# Altium schematic sheet Power.SchDoc(Power)
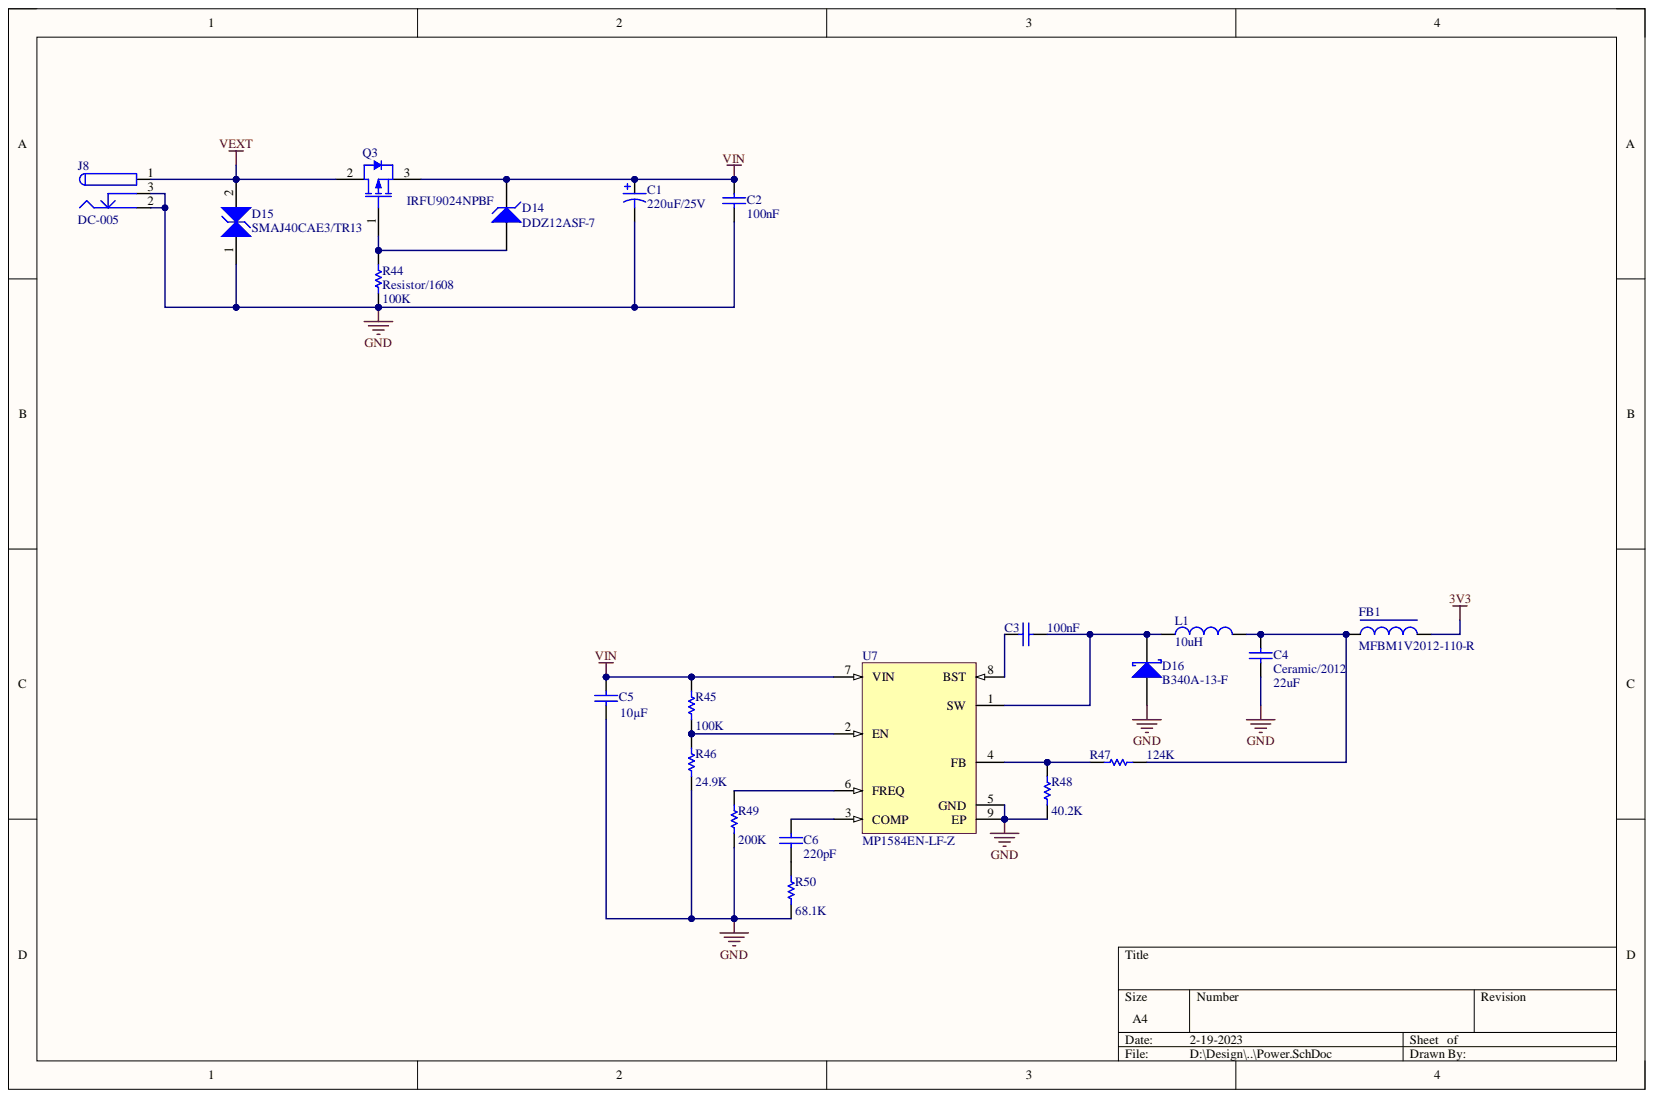
[diagram p0: Power.SchDoc]

## Parts
C1  value 220uF/25V  [THT Electrolytic 8x12x3.5mm]  (hidden comment: THT Electrolytic 8x12)
C2  value 100nF  [Generic 1608 Ceramic]  (hidden comment: Ceramic/1608)
C3  value 100nF  [Generic 1608-Footprint-1]  (hidden comment: Ceramic/1608)
C4  Ceramic/2012  value 22uF  [Ceramic_2012-Footprint-1]
C5  Ceramic/2012  value 10µF  [Ceramic_2012-Footprint-1]
C6  value 220pF  [Generic 1608-Footprint-1]  (hidden comment: Ceramic/1608)
D14  DDZ12ASF-7  [Diodes SOD323F-1]
D15  SMAJ40CAE3/TR13  [Microsemi_Bidirectional_SMA]
D16  B340A-13-F  [DIOD-SMA-2_V]
FB1  MFBM1V2012-110-R  [2508051027Y1-Footprint-1]
J8  DC-005  [DC-0005-Footprint-1]
L1  value 10uH  [YC104RT-10uH-Footprint-1]  (hidden comment: YC104RT-100M)
Q3  IRFU9024NPBF  [I-PAK_Vertical]
R44  Resistor/1608  value 100K  [Resistor 1608-Footprint]
R45  value 100K  [Generic 1608-Footprint-1]  (hidden comment: Generic 1608)
R46  value 24.9K  [Generic 1608-Footprint-1]  (hidden comment: Generic 1608)
R47  value 124K  [Generic 1608-Footprint-1]  (hidden comment: Generic 1608)
R48  value 40.2K  [Generic 1608-Footprint-1]  (hidden comment: Generic 1608)
R49  value 200K  [Generic 1608-Footprint-1]  (hidden comment: Generic 1608)
R50  value 68.1K  [Generic 1608-Footprint-1]  (hidden comment: Generic 1608)
U7  MP1584EN-LF-Z  [MP1584EN-LF-Z]

## Nets
3V3: FB1.2
GND: C1.2 C2.1 C4.1 C5.1 D15.1 D16.1 J8.2 J8.3 R44.1 R46.1 R48.1 R49.1 R50.1 U7.5(GND) U7.9(EP)
VEXT: D15.2 J8.1 Q3.2
VIN: C1.1 C2.2 C5.2 D14.1 Q3.3 R45.2 U7.7(VIN)
NetC3_1: C3.1 U7.8(BST)
NetC3_2: C3.2 D16.2 L1.1 U7.1(SW)
NetC4_2: C4.2 FB1.1 L1.2 R47.1
NetC6_1: C6.1 R50.2
NetC6_2: C6.2 U7.3(COMP)
NetD14_2: D14.2 Q3.1 R44.2
NetR45_1: R45.1 R46.2 U7.2(EN)
NetR47_2: R47.2 R48.2 U7.4(FB)
NetR49_2: R49.2 U7.6(FREQ)

## Library data of the parts
C1 = CMP-0001-00007-4: Generic THT Electrolytic capacitor; Capacitor Type: Electrolythic; Case/Package: Various; Component Kind: Standard; Library Name: C6H6`s Vault; Mount: THT; Pins: 2; RoHS: Yes
C2 = CMP-002-0009-3: Generic 1608 Ceramic Capacitor; Capacitor Type: Ceramic; Case/Package: 1608; Component Kind: Standard; Library Name: C6H6`s Vault; Mount: SMD; Pins: 2; RoHS: Yes
C3 C6 = CMP-002-0009-2: Generic 1608 Ceramic Capacitor; Capacitor Type: Ceramic; Case/Package: 1608; Component Kind: Standard; Library Name: C6H6`s Vault; Mount: SMD; Pins: 2; RoHS: Yes
C4 C5 = CMP-002-0022-1: Generic 2012 Ceramic Capacitor; Capacitor Type: Ceramic; Case/Package: 2012; Component Kind: Standard; Library Name: C6H6`s Vault; Mount: SMD; Pins: 2; RoHS: Yes
D14 = CMP-016-0031-1: Diode Zener Single 11.42V 3% 500mW 2-Pin SOD-323F T/R; Forward Voltage: 900mV; Impedance: 35R; Max Reverse Current: 70nA; Test Current: 10mA; Voltage Tolerance: 2.54%; Component Kind: Standard; Element Configuration: Single; Height: 0.75mm; Lead Free: Lead Free; Length: 2.5mm; Library Name: C6H6`s Vault; Max Operating Temperature: 150°C; Max Power Dissipation: 500mW; Max Reverse Leakage Current: 70nA; Min Operating Temperature: -65°C; Mount: Surface Mount; Number of Pins: 2; Package Quantity: 3000; Packaging: Tape and Reel; Power Rating: 500mW; Radiation Hardening: No; Reach SVHC Compliant: Yes; RoHS Compliant: Yes; Series: -; Tolerance: 3%; Width: 1.25mm; Zener Current: 70nA; Zener Voltage: 11.42V
D15 = CMP-00866-1: Diode TVS Single Bi-Dir 40V 500W 2-Pin DO-214AC T/R; Component Kind: Standard; Library Name: C6H6`s Vault
D16 = CMP-016-0009-1: DIODE SCHOTTKY 40V 3A SMA; Capacitance: 250pF; Element Configuration: Single; Forward Voltage: 500mV; Max Forward Surge Current (Ifsm): 80A; Peak Reverse Current: 500uA; Polarity: Standard; Average Rectified Current: 3A; Case/Package: SMA; Component Kind: Standard; Contact Plating: Tin,Matte; Current: 3A; Current Rating: 3A; Forward Current: 3A; Height: 2.3mm; Lead Free: Lead Free; Length: 4.6mm; Library Name: C6H6`s Vault; Max Operating Temperature: 125°C; Max Repetitive Reverse Voltage (Vrrm): 40V; Max Reverse Voltage (DC): 40V; Min Operating Temperature: -55°C; Mount: Surface Mount; Number of Pins: 2; Output Current: 3A; Package Quantity: 5000; Packaging: Tape and Reel; Peak Non-Repetitive Surge Current: 80A; Radiation Hardening: No; REACH SVHC: No SVHC; Termination: SMD/SMT; Voltage: 40V; Voltage Rating (DC): 40V; Weight: 0.002258oz; Width: 2.92mm
FB1 = CMP-ROOT-0224-1: Ferrite Chip Bead 11Ohm 6A 0.01Ohm Multi-Layer 2-Pin 0805 T/R; Test Frequency: 100MHz; Tolerance: 25%; Case/Package: 2012; Component Kind: Standard; Library Name: C6H6`s Vault; Termination: SMD/SMT; Width: 1.25mm
J8 = CMP-003-0019-2: DC Adapter Jack 2.0mm; Component Kind: Standard; Library Name: C6H6`s Vault
L1 = CMP-ROOT-00008-2: YC104RT-10uH 4.4A; Case/Package: 1040; Component Kind: Standard; Library Name: C6H6`s Vault; Mount: SMD; Pins: 2; RoHS: Yes
Q3 = CMP-014-0022-2: MOSFET P-CH 55V 11A I-PAK; Current Rating: -1.6A; Drain to Source Breakdown Voltage: -55V; Drain to Source Resistance: 175mR; Element Configuration: Single; Fall Time: 37ns; Gate to Source Voltage (Vgs): 20V; Input Capacitance: 350pF; Max Power Dissipation: 38W; Nominal Vgs: -4V; Recovery Time: 71ns; Rise Time: 55ns; Turn-Off Delay Time: 23ns; Turn-On Delay Time: 13ns; Voltage Rating (DC): -60V; Case/Package: TO-251-3; Component Kind: Standard; Continuous Drain Current (ID): 11A; Drain to Source Voltage (Vdss): 55V; Dual Supply Voltage: -55V; Height: 6.22mm; Lead Free: Lead Free; Length: 6.73mm; Library Name: C6H6`s Vault; Max Operating Temperature: 150°C; Min Operating Temperature: -55°C; Mount: Through Hole; Number of Pins: 3; On-State Resistance: 175mR; Package Quantity: 75; Packaging: Rail/Tube; Power Dissipation: 38W; Radiation Hardening: No; Rds On Max: 175mR; REACH SVHC: No SVHC; Termination: Through Hole; Width: 2.39mm
R44 = CMP-001-0018-2: Generic 1608 Resistor; Case/Package: Bulk; Component Kind: Standard; Library Name: C6H6`s Vault; Mount: SMD; Pins: 2; RoHS: Yes
R45-R50 = CMP-001-0018-1: Generic 1608 Resistor; Case/Package: Bulk; Component Kind: Standard; Library Name: C6H6`s Vault; Mount: SMD; Pins: 2; RoHS: Yes
U7 = CMP-00315-1: Switching Voltage Regulators 3A 1.5MHz 28V Nonsync Buck; Max Output Voltage: 25V; Min Input Voltage: 4.5V; Min Output Voltage: 800mV; Number of Outputs: 1; Output Configuration: Positive; Output Type: Adjustable; Synchronous Rectifier: No; Case/Package: SOIC; Component Kind: Standard; Contact Plating: Tin,Matte; Lead Free: Lead Free; Library Name: C6H6`s Vault; Max Input Voltage: 28V; Max Operating Temperature: 85°C; Max Output Current: 3A; Min Operating Temperature: -20°C; Mount: Surface Mount; Number of Pins: 8; Operating Supply Voltage: 28V; Output Current: 3A; Output Voltage: 25V; Package Quantity: 2500; Packaging: Tape and Reel; Switching Frequency: 1.5MHz
